# Altium schematic sheet Camera_MUX.SchDoc
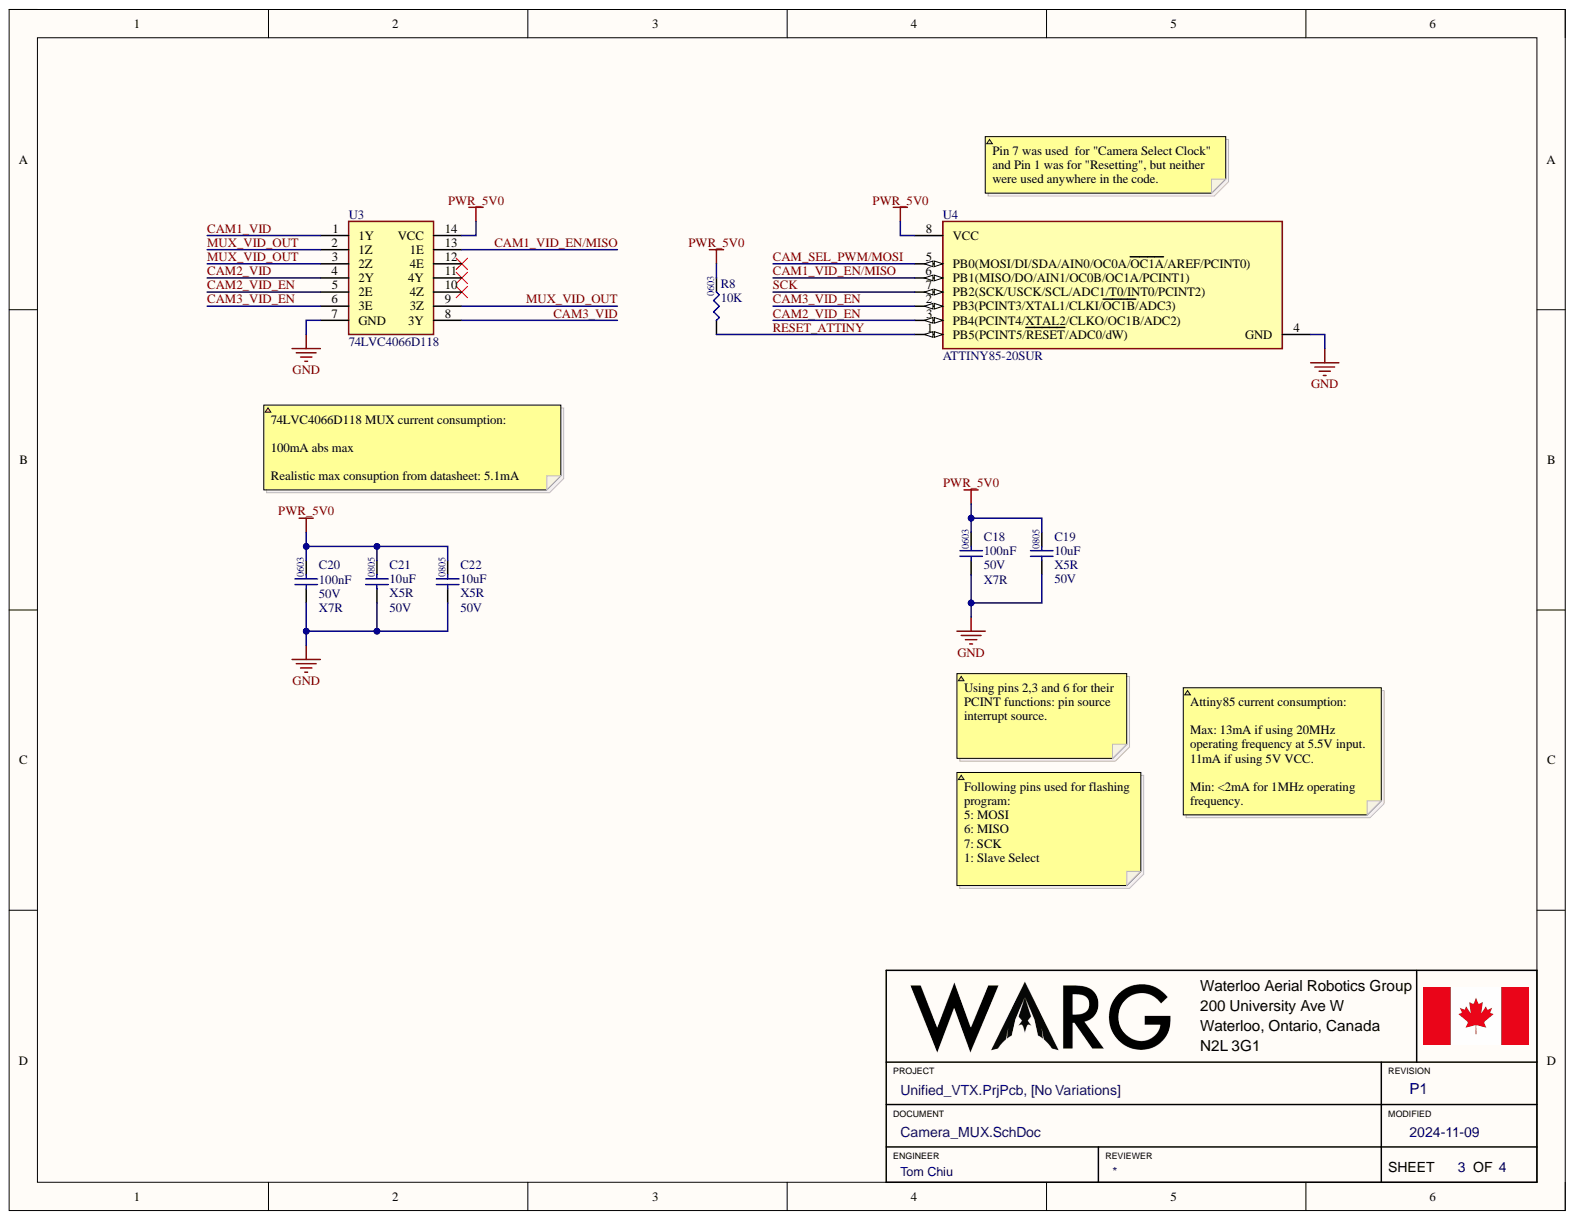
[diagram p0: Camera_MUX.SchDoc]

## Parts
C18  value 100nF  Temperature Coefficient: X7R  Voltage Rating: 50V  [.CAP_0603-Footprint-1]  (hidden comment: CGA3E2X7R1H104K080AA)
C19  value 10uF  Temperature Coefficient: X5R  Voltage Rating: 50V  [.CAP_0805-Footprint-1]  (hidden comment: GRM21BR61H106KE43L)
C20  value 100nF  Temperature Coefficient: X7R  Voltage Rating: 50V  [.CAP_0603-Footprint-1]  (hidden comment: CGA3E2X7R1H104K080AA)
C21  value 10uF  Temperature Coefficient: X5R  Voltage Rating: 50V  [.CAP_0805-Footprint-1]  (hidden comment: GRM21BR61H106KE43L)
C22  value 10uF  Temperature Coefficient: X5R  Voltage Rating: 50V  [.CAP_0805-Footprint-1]  (hidden comment: GRM21BR61H106KE43L)
R8  value 10K  [.RES_0603-Footprint-1]  (hidden comment: RC0603JR-1010KL)
U3  74LVC4066D118  [74LVC4066D118]
U4  ATTINY85-20SUR  [ATTINY85-20SUR]

## Nets
GND: C18.1 C19.1 C20.1 C21.1 C22.1 U3.7(GND) U4.4(GND)
PWR_5V0: C18.2 C19.2 C20.2 C21.2 C22.2 R8.2 U3.14(VCC) U4.8(VCC)
CAM1_VID: U3.1(1Y)
CAM1_VID_EN/MISO: U3.13(1E) U4.6(PB1(MISO/DO/AIN1/OC0B/OC1A/PCINT1))
CAM2_VID: U3.4(2Y)
CAM2_VID_EN: U3.5(2E) U4.3(PB4(PCINT4/XTAL2/CLKO/OC1B/ADC2))
CAM3_VID: U3.8(3Y)
CAM3_VID_EN: U3.6(3E) U4.2(PB3(PCINT3/XTAL1/CLKI/OC1B/ADC3))
CAM_SEL_PWM/MOSI: U4.5(PB0(MOSI/DI/SDA/AIN0/OC0A/OC1A/AREF/PCINT0))
MUX_VID_OUT: U3.2(1Z) U3.3(2Z) U3.9(3Z)
RESET_ATTINY: R8.1 U4.1(PB5(PCINT5/RESET/ADC0/dW))
SCK: U4.7(PB2(SCK/USCK/SCL/ADC1/T0/INT0/PCINT2))

Not connected: U3 10(4Z) 11(4Y) 12(4E)

## Library data of the parts
C18 C20 = CMP-00227-5: CAP CER 0.1UF 50V X7R 0603; Component Kind: Standard; Library Name: WARG
C19 C21 C22 = CMP-001-00065-3: CAP CER 10UF 50V X5R 0805; Component Kind: Standard; Library Name: WARG
R8 = CMP-009-00039-1: RES 10K OHM 5% 1/10W 0603; Component Kind: Standard; Library Name: WARG
U3 = CMP-00288-2: IC SWITCH SPST-NO X 4 10OHM 14SO; Multiplexer/Demultiplexer Circuit: 1:1; Number of Circuits: 4; Supply Voltage Range: 1.65V ~ 5.5V; Throw Configuration: SPST; Component Kind: Standard; Operating Temperature Range: -40°C ~ 125°C; Pins: 14; Library Name: WARG
U4 = CMP-00289-1: IC MCU 8BIT 8KB FLASH 8SOIC; Clock Frequency: 20MHz; Max Supply Current: 8mA; Number of I/O Lines: 6; Supply Voltage Range: 4.5V ~ 5.5V; Case/Package: 8-SOIC; Component Kind: Standard; Operating Temperature Range: -40°C ~ 85°C; Pins: 8; Technology: CMOS; Library Name: WARG
